# Altium schematic sheet Interfaces.SchDoc
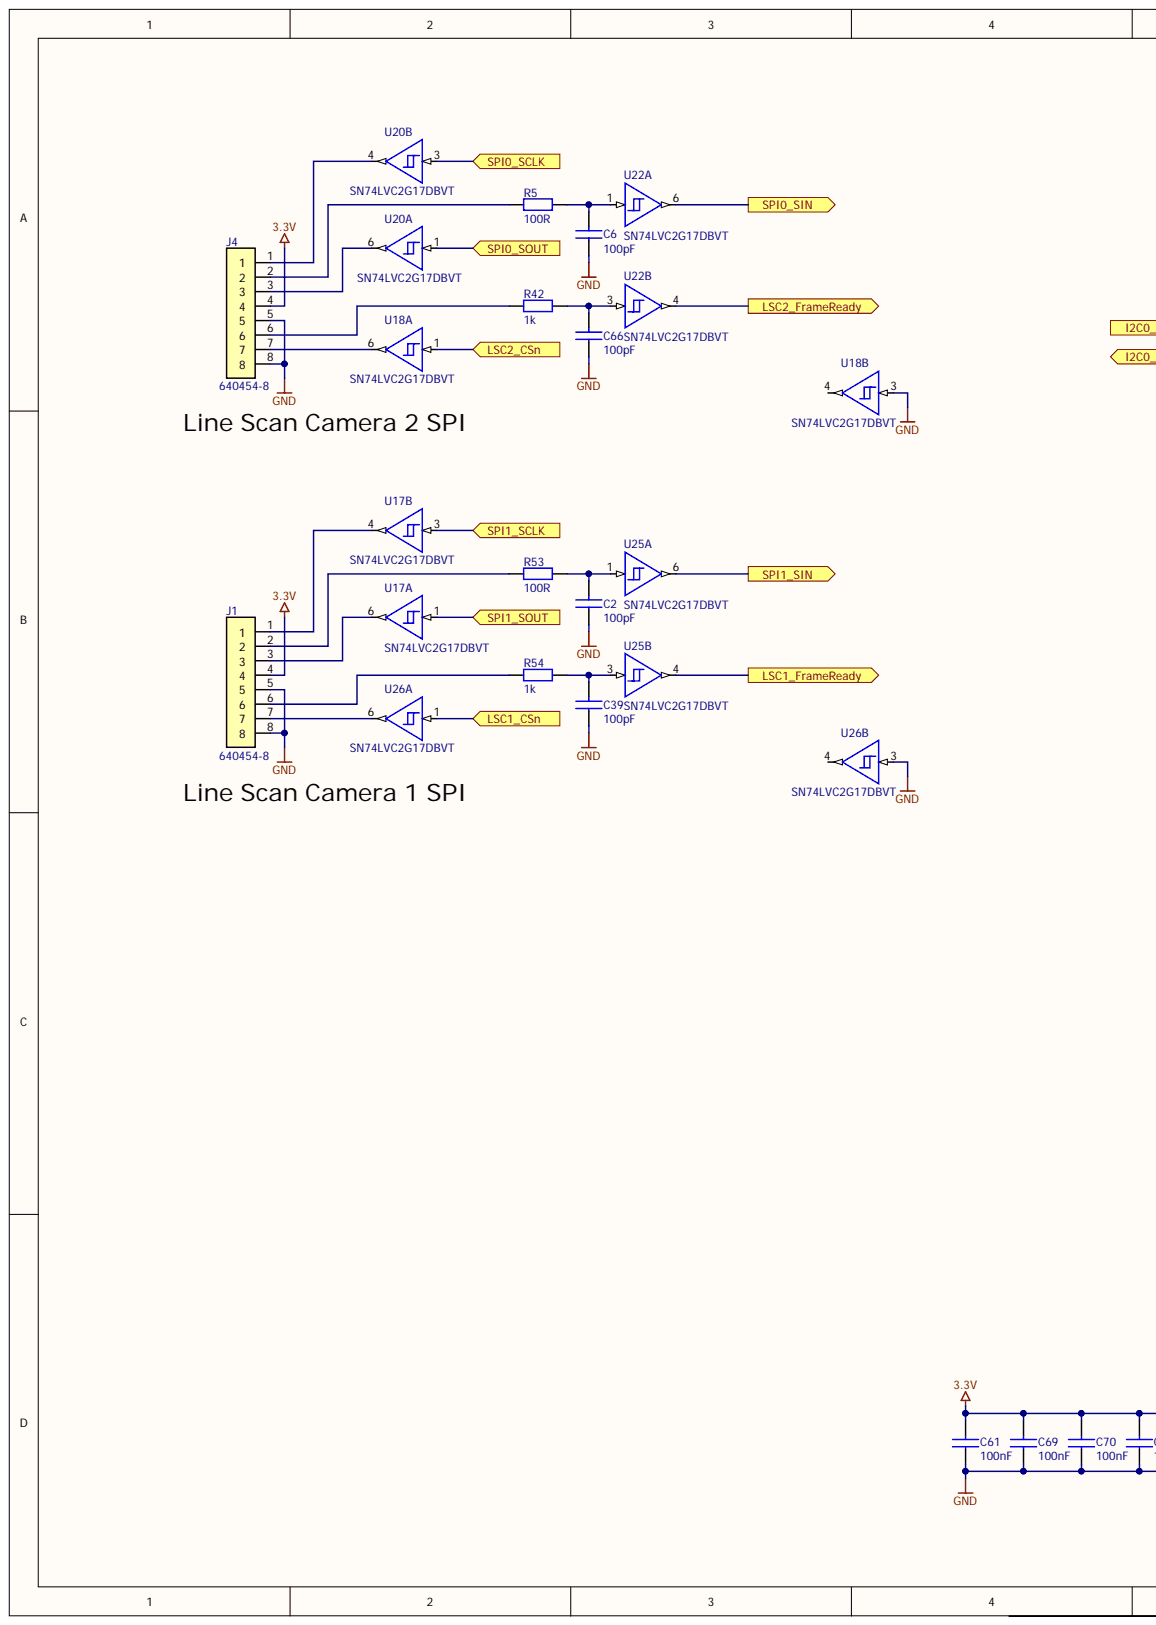
[diagram p0: Interfaces.SchDoc, left]
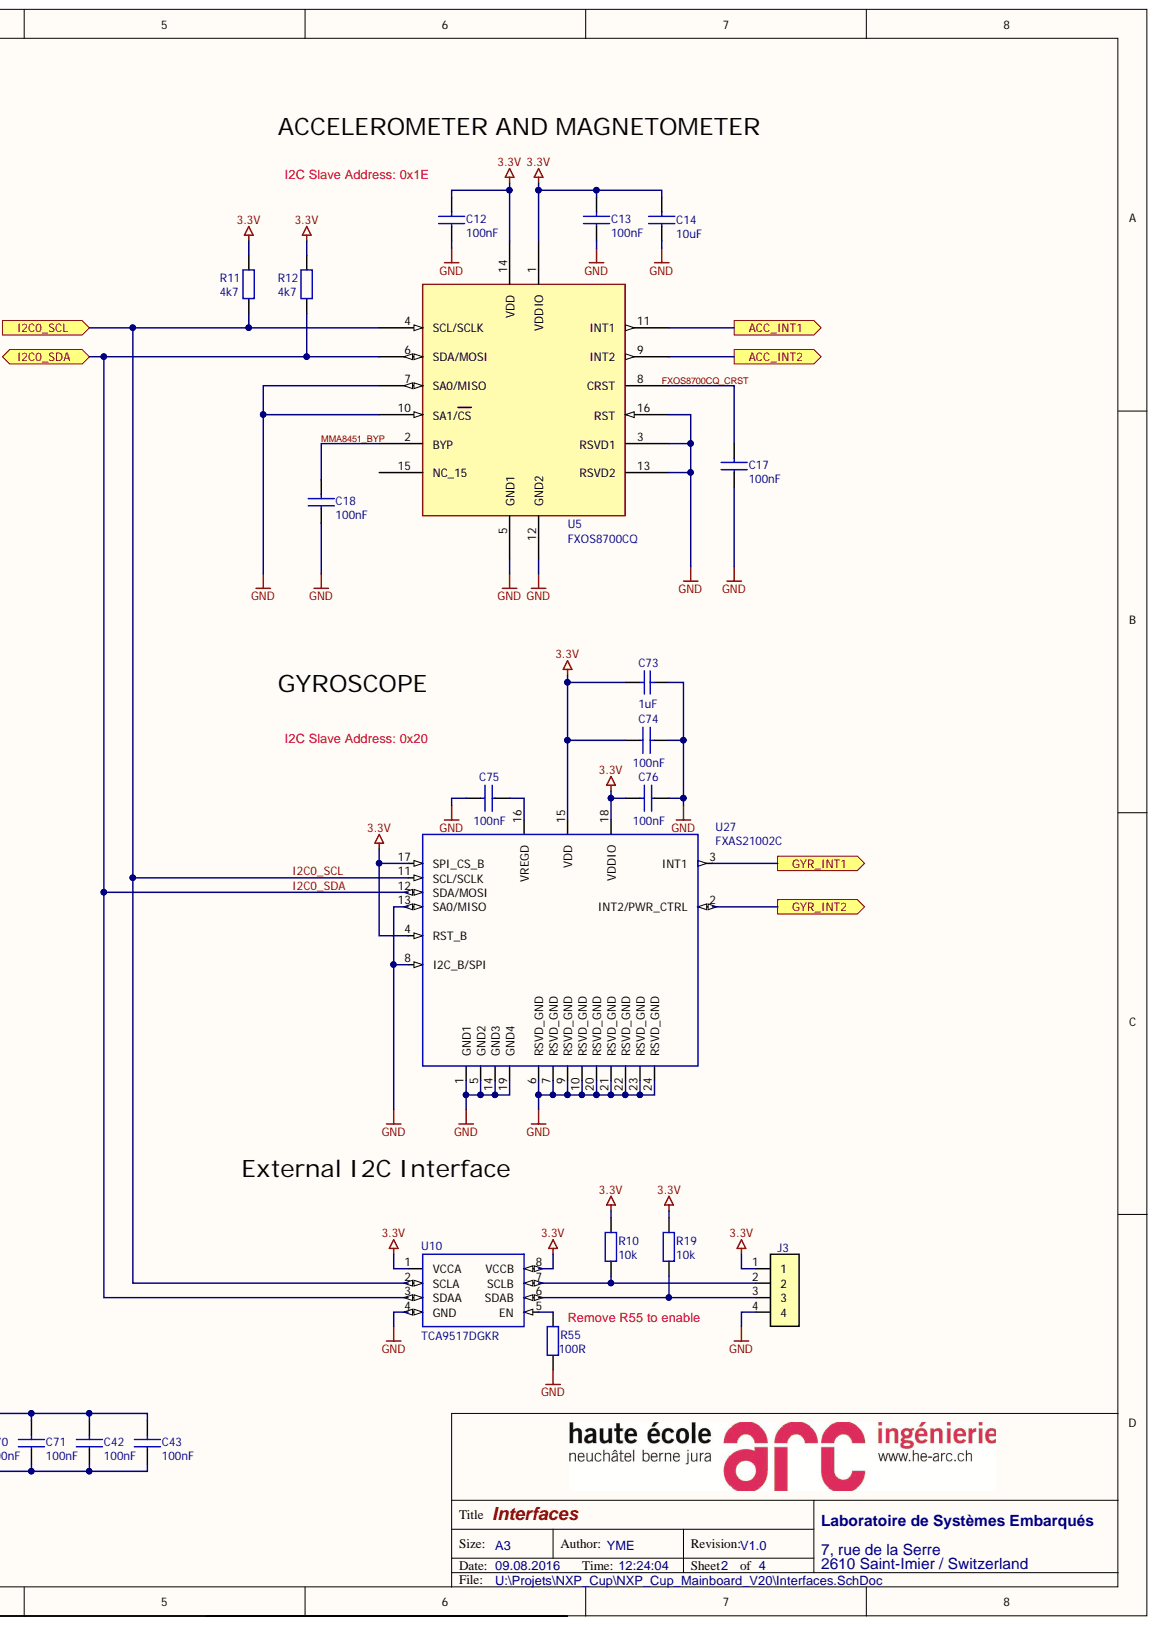
[diagram p1: Interfaces.SchDoc, right]

## Parts
C2  100pF  [060301]
C6  100pF  [060301]
C12  100nF  [060301]
C13  100nF  [060301]
C14  10uF  [080501]
C17  100nF  [060301]
C18  100nF  [060301]
C39  100pF  [060301]
C42  100nF  [060301]
C43  100nF  [060301]
C61  100nF  [060301]
C66  100pF  [060301]
C69  100nF  [060301]
C70  100nF  [060301]
C71  100nF  [060301]
C73  1uF  [060301]
C74  100nF  [060301]
C75  100nF  [060301]
C76  100nF  [060301]
J1  640454-8  [640454-8]
J3  68000-204HLF  [J104A101]  (value not drawn)
J4  640454-8  [640454-8]
R5  100R  [060301]
R10  10k  [060301]
R11  4k7  [060301]
R12  4k7  [060301]
R19  10k  [060301]
R42  1k  [060301]
R53  100R  [060301]
R54  1k  [060301]
R55  100R  [060301]
U5  FXOS8700CQ  [qfn5x3_0p5_3x3]
U10  TCA9517DGKR  [MSOP8]
U17  SN74LVC2G17DBVT  [SOT23601]  (parts A,B)
U18  SN74LVC2G17DBVT  [SOT23601]  (parts A,B)
U20  SN74LVC2G17DBVT  [SOT23601]  (parts A,B)
U22  SN74LVC2G17DBVT  [SOT23601]  (parts A,B)
U25  SN74LVC2G17DBVT  [SOT23601]  (parts A,B)
U26  SN74LVC2G17DBVT  [SOT23601]  (parts A,B)
U27  FXAS21002C  [QFN24]

## Ports
ACC_INT1, ACC_INT2, GYR_INT1, GYR_INT2, I2C0_SCL, I2C0_SDA, LSC1_CSN, LSC1_FRAMEREADY, LSC2_CSN, LSC2_FRAMEREADY, SPI0_SCLK, SPI0_SIN, SPI0_SOUT, SPI1_SCLK, SPI1_SIN, SPI1_SOUT

## Nets
3.3V: C12.2 C13.2 C14.2 C42.2 C43.2 C61.2 C69.2 C70.2 C71.2 C73.1 C74.1 C76.2 J1.4 J3.1 J4.4 R10.1 R11.2 R12.2 R19.1 U5.1(VDDIO) U5.14(VDD) U10.1(VCCA) U10.8(VCCB) U17.5 U18.5 U20.5 U22.5 U25.5 U26.5 U27.4(RST_B) U27.15(VDD) U27.17(SPI_CS_B) U27.18(VDDIO)
GND: C2.1 C6.1 C12.1 C13.1 C14.1 C17.1 C18.1 C39.1 C42.1 C43.1 C61.1 C66.1 C69.1 C70.1 C71.1 C73.2 C74.2 C75.2 C76.1 J1.5 J1.8 J3.4 J4.5 J4.8 R55.1 U5.3(RSVD1) U5.5(GND1) U5.7(SA0/MISO) U5.10(SA1/CS) U5.12(GND2) U5.13(RSVD2) U5.16(RST) U10.4(GND) U17.2 U18.2 U18.3 U20.2 U22.2 U25.2 U26.2 U26.3 U27.1(GND1) U27.5(GND2) U27.6(RSVD_GND) U27.7(RSVD_GND) U27.8(I2C_B/SPI) U27.9(RSVD_GND) U27.10(RSVD_GND) U27.13(SA0/MISO) U27.14(GND3) U27.19(GND4) U27.20(RSVD_GND) U27.21(RSVD_GND) U27.22(RSVD_GND) U27.23(RSVD_GND) U27.24(RSVD_GND)
LSC1_CSN: U26.1 ; port LSC1_CSN
LSC1_FRAMEREADY: U25.4 ; port LSC1_FRAMEREADY
LSC2_CSN: U18.1 ; port LSC2_CSN
LSC2_FRAMEREADY: U22.4 ; port LSC2_FRAMEREADY
ACC_INT1: U5.11(INT1) ; port ACC_INT1
ACC_INT2: U5.9(INT2) ; port ACC_INT2
GYR_INT1: U27.3(INT1) ; port GYR_INT1
GYR_INT2: U27.2(INT2/PWR_CTRL) ; port GYR_INT2
I2C0_SCL: R11.1 U5.4(SCL/SCLK) U10.2(SCLA) U27.11(SCL/SCLK) ; port I2C0_SCL
I2C0_SDA: R12.1 U5.6(SDA/MOSI) U10.3(SDAA) U27.12(SDA/MOSI) ; port I2C0_SDA
MMA8451_BYP: C18.2 U5.2(BYP)
SPI0_SCLK: U20.3 ; port SPI0_SCLK
SPI0_SIN: U22.6 ; port SPI0_SIN
SPI0_SOUT: U20.1 ; port SPI0_SOUT
SPI1_SCLK: U17.3 ; port SPI1_SCLK
SPI1_SIN: U25.6 ; port SPI1_SIN
SPI1_SOUT: U17.1 ; port SPI1_SOUT
NetC2_2: C2.2 R53.1 U25.1
NetC6_2: C6.2 R5.1 U22.1
NetC17_2: C17.2 U5.8(CRST)
NetC39_2: C39.2 R54.1 U25.3
NetC66_2: C66.2 R42.1 U22.3
NetC75_1: C75.1 U27.16(VREGD)
NetJ1_1: J1.1 U17.4
NetJ1_2: J1.2 R53.2
NetJ1_3: J1.3 U17.6
NetJ1_6: J1.6 R54.2
NetJ1_7: J1.7 U26.6
NetJ3_2: J3.2 R10.2 U10.7(SCLB)
NetJ3_3: J3.3 R19.2 U10.6(SDAB)
NetJ4_1: J4.1 U20.4
NetJ4_2: J4.2 R5.2
NetJ4_3: J4.3 U20.6
NetJ4_6: J4.6 R42.2
NetJ4_7: J4.7 U18.6
NetR55_2: R55.2 U10.5(EN)
FXOS8700CQ_CRST:

Not connected: U5 15(NC_15); U18 4; U26 4

## Library data of the parts
C2 C6 C39 C66 = CAPA: CAP CER 100PF 50V 5% NP0 0603; Component Kind: Standard; Supplier 1: Digi-Key; Supplier Part Number 1: 445-1281-1-ND; Value: 100pF; Voltage: 50V; Library Name: EISI.SchLib
C12 C13 C17 C18 C42 C43 C61 C69-C71 C74-C76 = CAPA: CAP CER 0.1UF 25V 10% X7R 0603; Component Kind: Standard; Supplier 1: Digi-Key; Supplier Part Number 1: 445-1316-1-ND; Value: 100nF; Voltage: 25V; Library Name: EISI.SchLib
C14 = CAPA: CAP CER 10UF 16V 20% X6S 0805; Component Kind: Standard; Supplier 1: Digi-Key; Supplier Part Number 1: 445-7612-2-ND; Value: 10uF; Library Name: EISI.SchLib
C73 = Cap Semi: CAP CER 1UF 16V 10% X7R 0603; Component Kind: Standard; Library Name: Miscellaneous Devices.IntLib; Signal Integrity: Cap Semi; Simulation: CAP; Supplier 1: Digi-Key; Supplier Part Number 1: 399-7847-6-ND; Value: 1uF
J1 J4 = 640454-8: CONN HEADER VERT 8POS .100 TIN; Component Kind: Standard; Supplier 1: Digi-Key; Supplier Part Number 1: A19435-ND; Library Name: FRDM-ARC.SCHLIB
J3 = 640454-4: CONN HEADER 4POS .100 STR 15AU; Component Kind: Standard; Supplier 1: Digi-Key; Supplier Part Number 1: 609-3255-ND; Library Name: FRDM-ARC.SCHLIB
R5 R53 R55 = RES: RES SMD 100 OHM 1% 1/10W 0603; Component Kind: Standard; Supplier 1: Digi-Key; Supplier Part Number 1: 311-100HRCT-ND; Value: 100R; Library Name: EISI.SchLib
R10 R19 = RES: RES 10K OHM 1/10W .1% 0603 SMD; Component Kind: Standard; Supplier 1: Digi-Key; Supplier Part Number 1: P10KDBTR-ND; Value: 10k; Library Name: EISI.SchLib
R11 R12 = RES: RES 4.7K OHM 1/10W 1% 0603 SMD; Component Kind: Standard; Supplier 1: Digi-Key; Supplier Part Number 1: P4.70KHTR-ND; Value: 4k7; Library Name: EISI.SchLib
R42 R54 = RES: RES 1.00K OHM 1/10W 1% 0603 SMD; Component Kind: Standard; Supplier 1: Digi-Key; Supplier Part Number 1: 311-1.00KHRTR-ND; Value: 1k; Library Name: EISI.SchLib
U5 = FXOS8700CQ_QFN_16P: IC ACCELEROMETER AND MAGNETOMETER SENSOR 3-AXIS 2.5V QFN16; Component Kind: Standard; Supplier 1: Digi-Key; Supplier Part Number 1: FXOS8700CQR1DKR-ND
U10 = TCA9517: IC I2C BUS REP LVL-TRANSL 8VSSOP; Component Kind: Standard; Supplier 1: Digi-Key; Supplier Part Number 1: 296-35972-6-ND; Library Name: EISI.SchLib
U17 U18 U20 U22 U25 U26 = SN74LVC2G17: IS BUFF DL SCHMIT TRIG SOT23-6; Component Kind: Standard; Supplier 1: Digi-Key; Supplier Part Number 1: 296-26621-2-ND; Library Name: EISI.SchLib
U27 = FXAS21002C: GYROSCOPE 3-AXIS 24QFN; Component Kind: Standard; Supplier 1: Digi-Key; Supplier Part Number 1: FXAS21002CQR1CT-ND; Library Name: NXP_Cup_Mainboard.SCHLIB
